# Altium schematic sheet LoRa_Elemon_5.SchDoc(LoRa_Elemon_5)
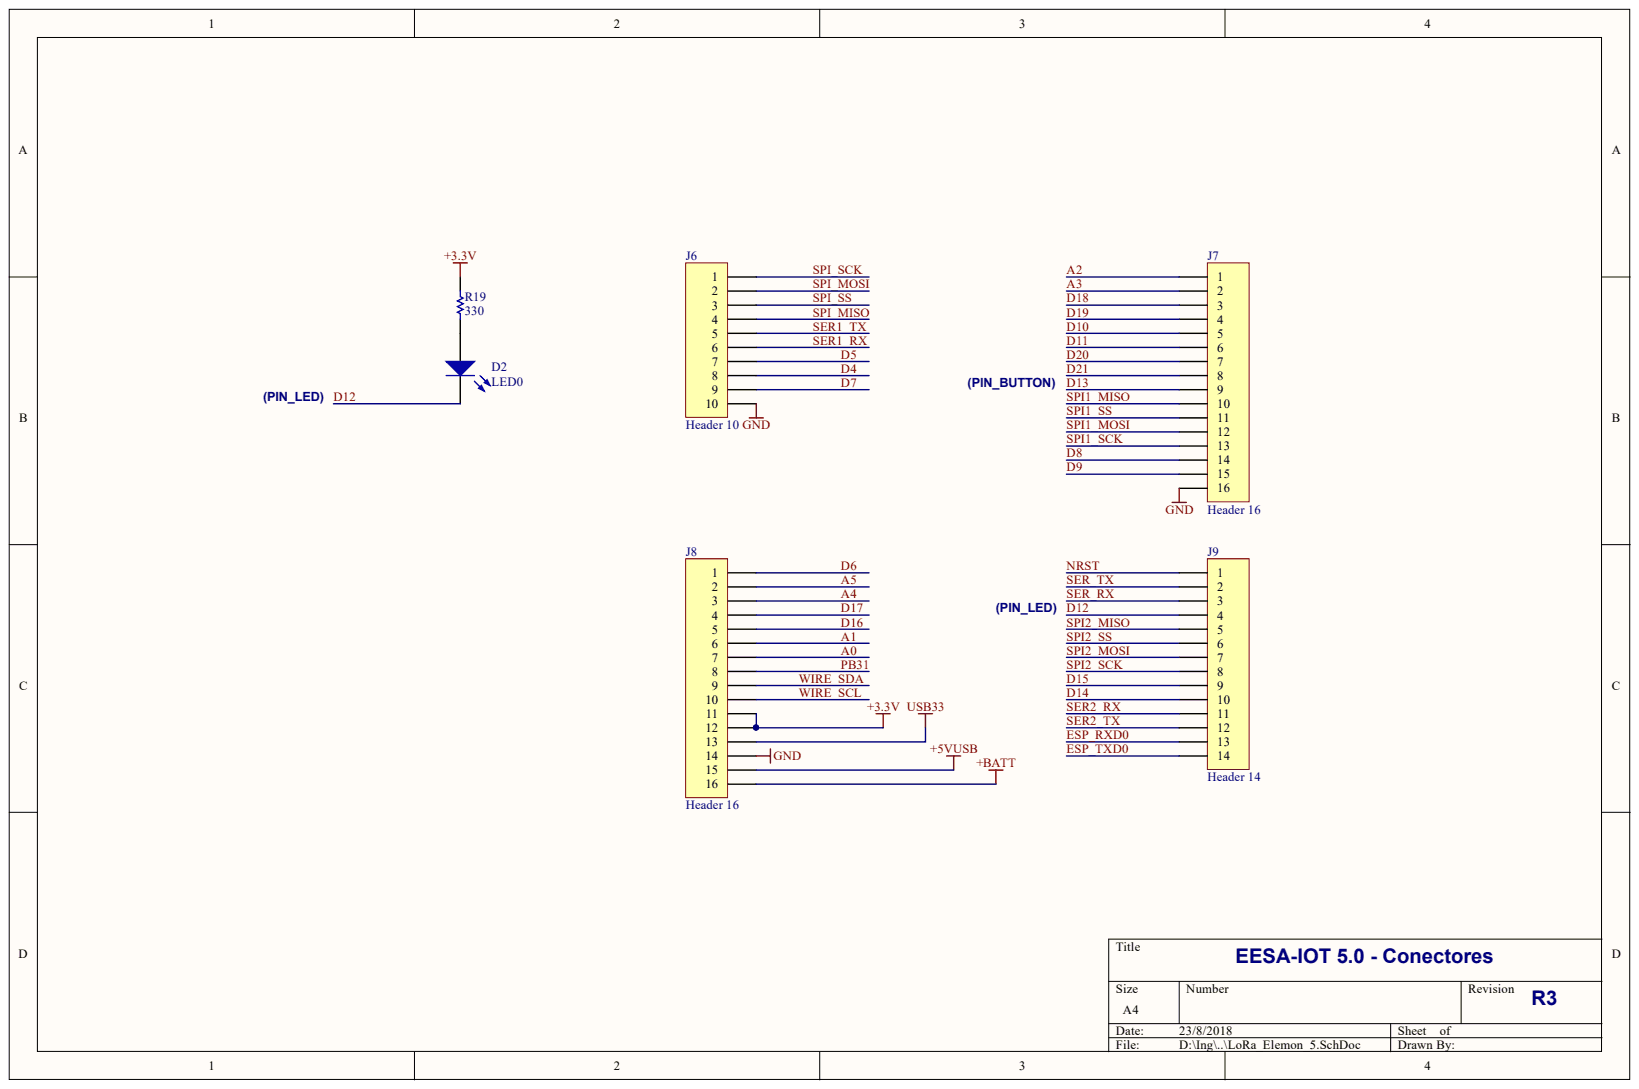
[diagram p0: LoRa_Elemon_5.SchDoc]

## Parts
D2  LED0  [0805]
J6  Header 10  [HDR1X10]
J7  Header 16  [HDR1X16]
J8  Header 16  [HDR1X16]
J9  Header 14  [HDR1X14]
R19  330  [0805]

## Nets
+3.3V: J8.11 J8.12 R19.2
+5VUSB: J8.15
+BATT: J8.16
GND: J6.10 J7.16 J8.14
USB33: J8.13
A0: J8.7
A1: J8.6
A2: J7.1
A3: J7.2
A4: J8.3
A5: J8.2
D4: J6.8
D5: J6.7
D6: J8.1
D7: J6.9
D8: J7.14
D9: J7.15
D10: J7.5
D11: J7.6
D12: D2.2 J9.4
D13: J7.9
D14: J9.10
D15: J9.9
D16: J8.5
D17: J8.4
D18: J7.3
D19: J7.4
D20: J7.7
D21: J7.8
ESP_RXD0: J9.13
ESP_TXD0: J9.14
NRST: J9.1
PB31: J8.8
SER1_RX: J6.6
SER1_TX: J6.5
SER2_RX: J9.11
SER2_TX: J9.12
SER_RX: J9.3
SER_TX: J9.2
SPI1_MISO: J7.10
SPI1_MOSI: J7.12
SPI1_SCK: J7.13
SPI1_SS: J7.11
SPI2_MISO: J9.5
SPI2_MOSI: J9.7
SPI2_SCK: J9.8
SPI2_SS: J9.6
SPI_MISO: J6.4
SPI_MOSI: J6.2
SPI_SCK: J6.1
SPI_SS: J6.3
WIRE_SCL: J8.10
WIRE_SDA: J8.9
NetD2_1: D2.1 R19.1

## Library data of the parts
D2 = LED0: Typical INFRARED GaAs LED; Component Kind: Standard; LatestRevisionDate: 08-Jul-2005; LatestRevisionNote: Mech Layer 1 removed.; Library Name: Miscellaneous Devices.IntLib; PackageDescription: LED; 2 Leads; PackageReference: LED-0; Published: 8-Jun-2000; Simulation: LED0; Publisher: Altium Limited
J6 = Header 10: Header, 10-Pin; Component Kind: Standard; LatestRevisionDate: 17-Jul-2002; LatestRevisionNote: Re-released for DXP Platform.; Library Name: Miscellaneous Connectors.IntLib; Signal Integrity: Connector; Publisher: Altium Limited
J7 J8 = Header 16: Header, 16-Pin; Component Kind: Standard; LatestRevisionDate: 17-Jul-2002; LatestRevisionNote: Re-released for DXP Platform.; Library Name: Miscellaneous Connectors.IntLib; Signal Integrity: Connector; Publisher: Altium Limited
J9 = Header 14: Header, 14-Pin; Component Kind: Standard; LatestRevisionDate: 17-Jul-2002; LatestRevisionNote: Re-released for DXP Platform.; Library Name: Miscellaneous Connectors.IntLib; Signal Integrity: Connector; Publisher: Altium Limited
R19 = Res1: Resistor; Value: 1K; Component Kind: Standard; LatestRevisionDate: 17-Jul-2002; LatestRevisionNote: Re-released for DXP Platform.; Library Name: Miscellaneous Devices.IntLib; PackageDescription: Axial Device, Thru-Hole; 2 Leads; 0.3 in Pin Spacing; PackageReference: AXIAL-0.3; Published: 8-Jun-2000; Signal Integrity: Res; Simulation: RESISTOR; Publisher: Altium Limited
